# Altium schematic sheet IMU&AI.SchDoc
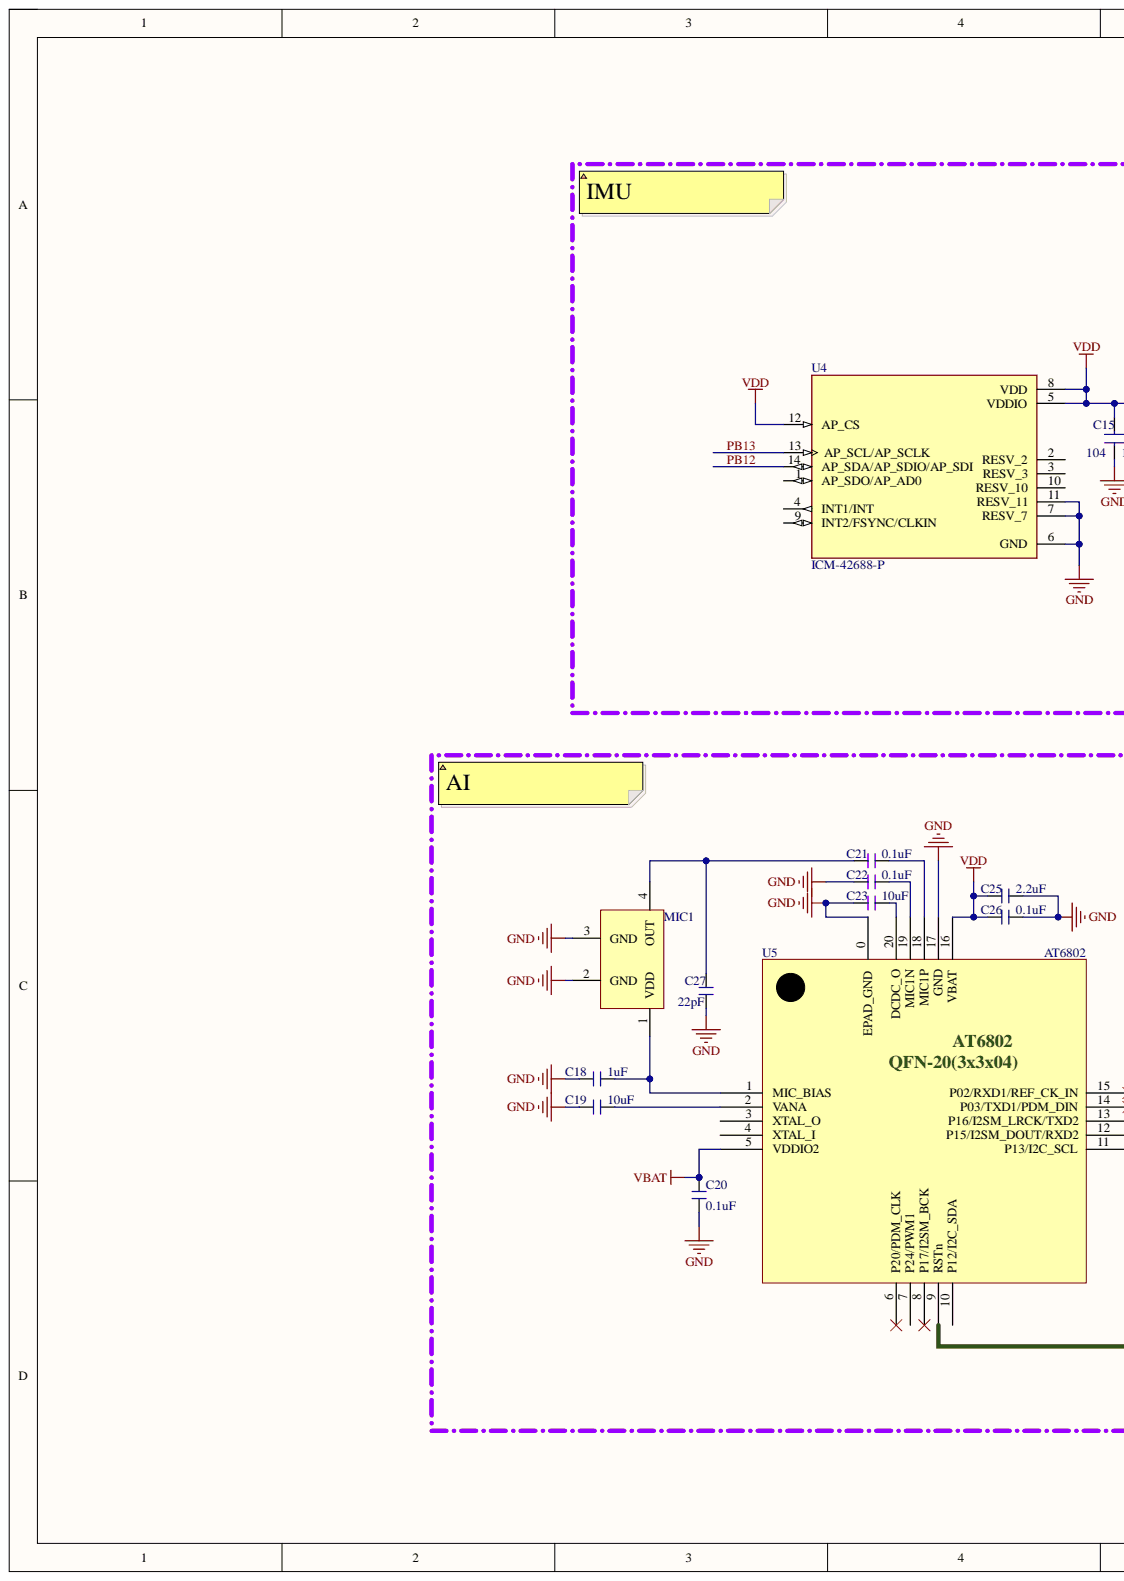
[diagram p0: IMU&AI.SchDoc, left]
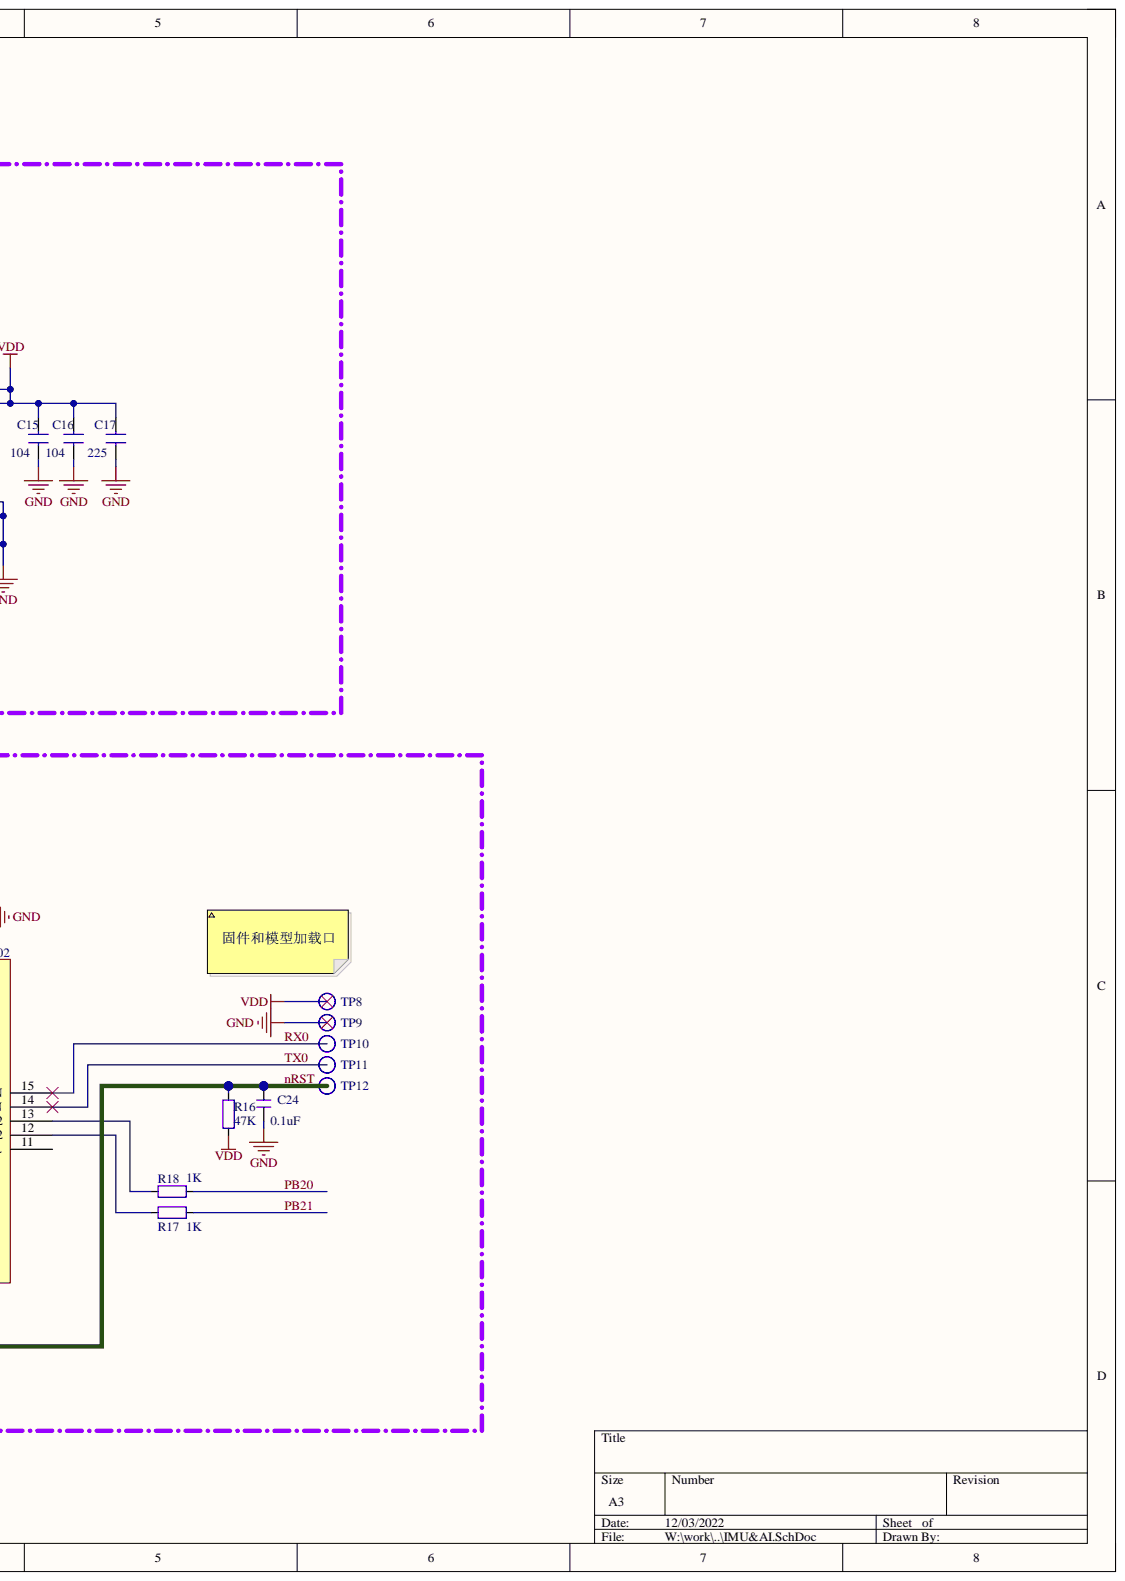
[diagram p1: IMU&AI.SchDoc, right]

## Parts
C15  104  [CAP_0402]
C16  104  [CAP_0402]
C17  225  [CAP_0402]
C18  1uF  [C0402]
C19  10uF  [C0402]
C20  0.1uF  [C0402]
C21  0.1uF  [C0402]
C22  0.1uF  [C0402]
C23  10uF  [C0402]
C24  0.1uF  [C0402]
C25  2.2uF  [C0402]
C26  0.1uF  [C0402]
C27  22pF  [C0402]
MIC1  MIC_2718_TOP_381  [MIC_2718-4P_AT]  (value not drawn)
R16  47K  [RES_0402]
R17  1K  [RES_0402]
R18  1K  [RES_0402]
U4  ICM-42688-P  [PQFN50P300X250X97-14N]
U5  AT6802  [QFN-20_3x3x04P]

## Nets
GND: C15.2 C16.2 C17.2 C18.2 C19.2 C20.2 C22.1 C23.1 C24.2 C25.1 C26.1 C27.2 MIC1.2(GND) MIC1.3(GND) U4.6(GND) U4.7(RESV_7) U4.11(RESV_11) U5.0(EPAD_GND) U5.17(GND)
VBAT: C20.1 U5.5(VDDIO2)
VDD: C15.1 C16.1 C17.1 C25.2 C26.2 R16.1 U4.5(VDDIO) U4.8(VDD) U4.12(AP_CS) U5.16(VBAT)
nRST: C24.1 R16.2 U5.9(RSTn)
PB12: U4.14(AP_SDA/AP_SDIO/AP_SDI)
PB13: U4.13(AP_SCL/AP_SCLK)
PB20: R18.1
PB21: R17.1
RX0: U5.15(P02/RXD1/REF_CK_IN)
TX0: U5.14(P03/TXD1/PDM_DIN)
NetC18_1: C18.1 MIC1.1(VDD) U5.1(MIC_BIAS)
NetC19_1: C19.1 U5.2(VANA)
NetC21_1: C21.1 C27.1 MIC1.4(OUT)
NetC21_2: C21.2 U5.18(MIC1P)
NetC22_2: C22.2 U5.19(MIC1N)
NetC23_2: C23.2 U5.20(DCDC_O)
NetR17_2: R17.2 U5.12(P15/I2SM_DOUT/RXD2)
NetR18_2: R18.2 U5.13(P16/I2SM_LRCK/TXD2)

Not connected: U4 1(AP_SDO/AP_AD0) 2(RESV_2) 3(RESV_3) 4(INT1/INT) 9(INT2/FSYNC/CLKIN) 10(RESV_10); U5 3(XTAL_O) 4(XTAL_I) 6(P20/PDM_CLK) 7(P24/PWM1) 8(P17/I2SM_BCK) 10(P12/I2C_SDA) 11(P13/I2C_SCL)

## Library data of the parts
C15-C17 = CAP: Component Kind: Standard
C18-C27 = C: Default Capacitor; Component Kind: Standard; Library Name: Altium Devices.Schlib
MIC1 = MIC_MSM321A3729H9HP‐C: Component Kind: Standard; Library Name: Altium Devices.Schlib
R16-R18 = 电阻-0402: Resistor; Value: 22R; Component Kind: Standard; Library Name: MyPcbLib.IntLib
U4 = ICM-42688-P: Accelerometer, Gyroscope, 6 Axis Sensor Output; MF: TDK InvenSense; Availability: Unavailable; Component Kind: Standard; Library Name: ICM-42688-P.IntLib; MP: ICM-42688-P; Package: - TDK InvenSense; Price: None; SnapEDA_Link: https://www.snapeda.com/parts/ICM-42688-P/TDK%20InvenSense/view-part/4791856/?ref=snap
U5 = AT6802: Component Kind: Standard; Library Name: Altium Devices.Schlib
